# Altium schematic sheet 17 RGMII2.SchDoc
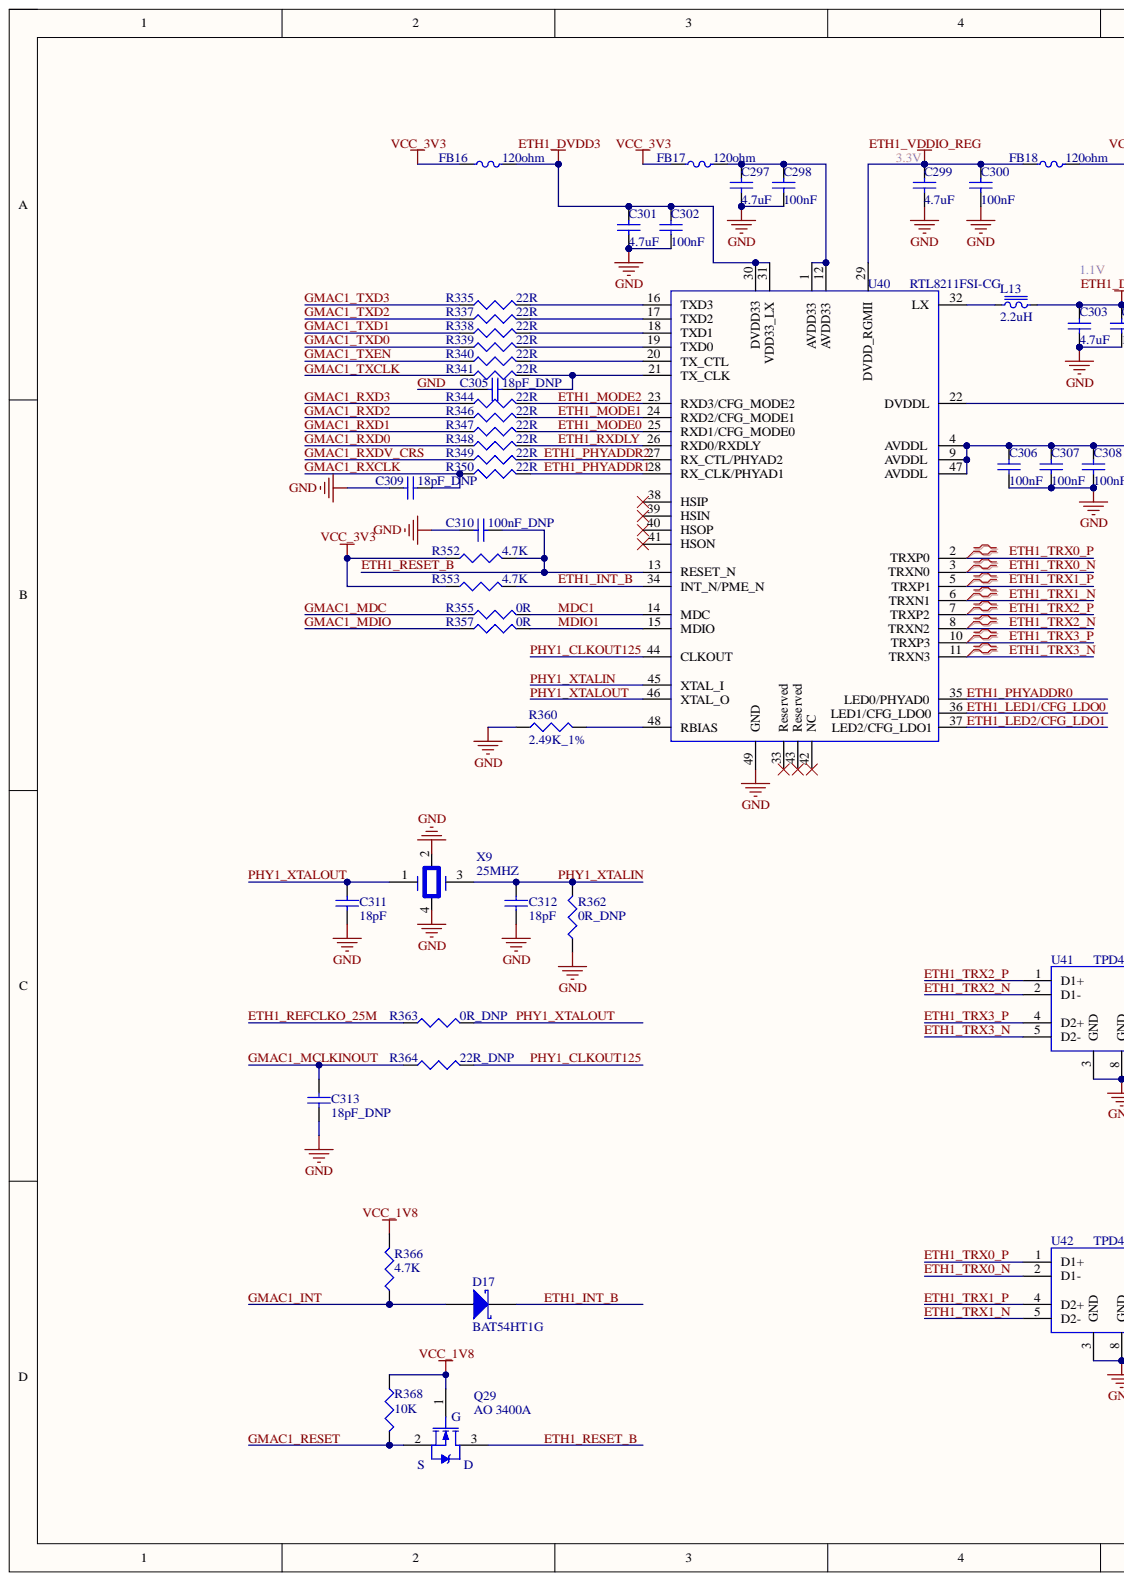
[diagram p0: 17 RGMII2.SchDoc, left]
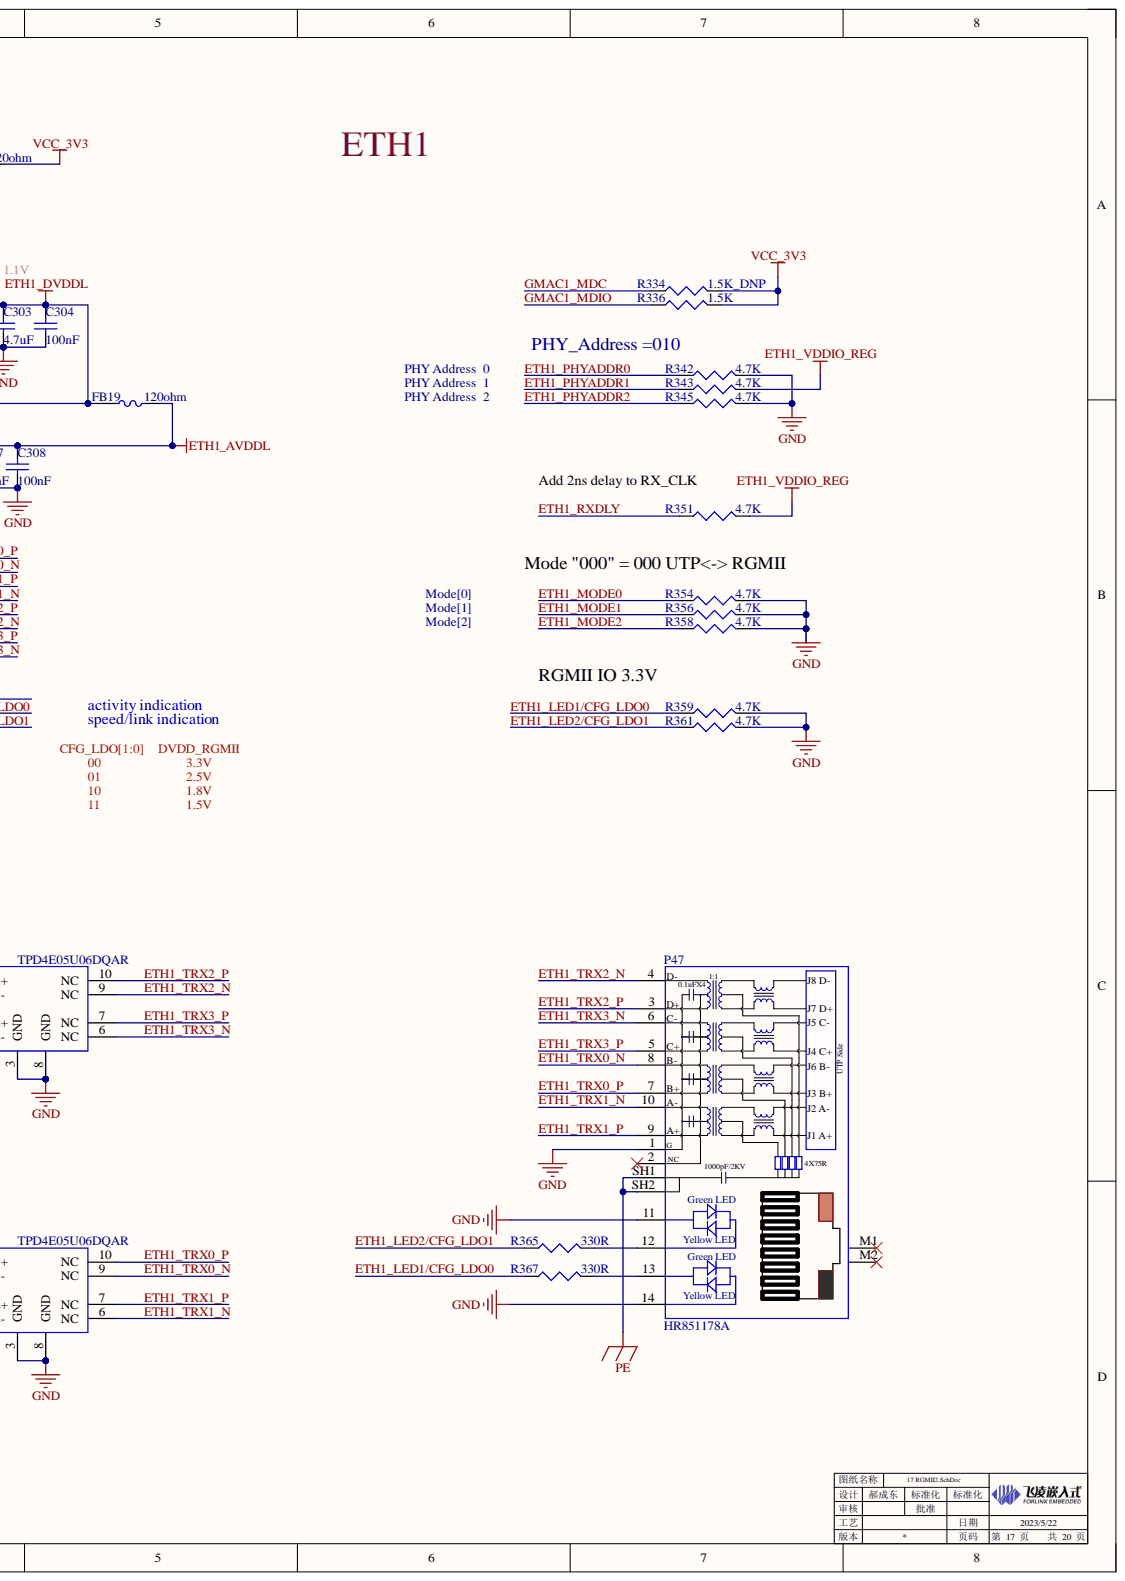
[diagram p1: 17 RGMII2.SchDoc, right]

## Parts
C297  4.7uF  [0402C]
C298  100nF  [0402C]
C299  4.7uF  [0402C]
C300  100nF  [0402C]
C301  4.7uF  [0402C]
C302  100nF  [0402C]
C303  4.7uF  [0402C]
C304  100nF  [0402C]
C305  18pF_DNP  [0402C]
C306  100nF  [0402C]
C307  100nF  [0402C]
C308  100nF  [0402C]
C309  18pF_DNP  [0402C]
C310  100nF_DNP  [0402C]
C311  18pF  [0402C]
C312  18pF  [0402C]
C313  18pF_DNP  [0402C]
D17  BAT54HT1G  [SOD_323]
FB16  120ohm  [0603L]
FB17  120ohm  [0603L]
FB18  120ohm  [0603L]
FB19  120ohm  [0603L]
L13  2.2uH  [WPN2016]
P47  HR851178A  [RJ45_Gigabit]
Q29  AO 3400A  [SOT_233_W]
R334  1.5K_DNP  [0402R]
R335  22R  [0402R]
R336  1.5K  [0402R]
R337  22R  [0402R]
R338  22R  [0402R]
R339  22R  [0402R]
R340  22R  [0402R]
R341  22R  [0402R]
R342  4.7K  [0402R]
R343  4.7K  [0402R]
R344  22R  [0402R]
R345  4.7K  [0402R]
R346  22R  [0402R]
R347  22R  [0402R]
R348  22R  [0402R]
R349  22R  [0402R]
R350  22R  [0402R]
R351  4.7K  [0402R]
R352  4.7K  [0402R]
R353  4.7K  [0402R]
R354  4.7K  [0402R]
R355  0R  [0402R]
R356  4.7K  [0402R]
R357  0R  [0402R]
R358  4.7K  [0402R]
R359  4.7K  [0402R]
R360  2.49K_1%  [0402R]
R361  4.7K  [0402R]
R362  0R_DNP  [0402R]
R363  0R_DNP  [0402R]
R364  22R_DNP  [0603R]
R365  330R  [0402R]
R366  4.7K  [0402R]
R367  330R  [0402R]
R368  10K  [0402R]
U40  RTL8211FSI-CG  [QFN48P_0.4BSC_6*6_H]
U41  TPD4E05U06DQAR  [USON-10]
U42  TPD4E05U06DQAR  [USON-10]
X9  25MHZ  [Crystals_3225]

## Nets
ETH1_AVDDL: C306.2 C307.2 C308.2 FB19.2 U40.4(AVDDL) U40.9(AVDDL) U40.47(AVDDL)
ETH1_DVDD3: C301.2 C302.2 FB16.2 U40.30(DVDD33) U40.31(VDD33_LX)
ETH1_DVDDL: C303.2 C304.2 FB19.1 L13.2 U40.22(DVDDL)
ETH1_VDDIO_REG: C299.2 C300.2 FB18.1 R343.2 R351.2 U40.29(DVDD_RGMII)
PE: P47.SH1 P47.SH2
VCC_1V8: Q29.1 R366.2 R368.1
VCC_3V3: FB16.1 FB17.1 FB18.2 R334.2 R336.2 R352.1 R353.1
ETH1_INT_B: D17.2 R353.2 U40.34(INT_N/PME_N)
ETH1_LED1/CFG_LDO0: R359.1 R367.1 U40.36(LED1/CFG_LDO0)
ETH1_LED2/CFG_LDO1: R361.1 R365.1 U40.37(LED2/CFG_LDO1)
ETH1_MODE0: R347.2 R354.1 U40.25(RXD1/CFG_MODE0)
ETH1_MODE1: R346.2 R356.1 U40.24(RXD2/CFG_MODE1)
ETH1_MODE2: R344.2 R358.1 U40.23(RXD3/CFG_MODE2)
ETH1_PHYADDR0: R342.1 U40.35(LED0/PHYAD0)
ETH1_PHYADDR1: R343.1 R350.2 U40.28(RX_CLK/PHYAD1)
ETH1_PHYADDR2: R345.1 R349.2 U40.27(RX_CTL/PHYAD2)
ETH1_REFCLKO_25M: R363.1
ETH1_RESET_B: C310.2 Q29.3 R352.2 U40.13(RESET_N)
ETH1_RXDLY: R348.2 R351.1 U40.26(RXD0/RXDLY)
ETH1_TRX0_N: P47.8(B-) U40.3(TRXN0) U42.2(D1-) U42.9(NC)
ETH1_TRX0_P: P47.7 U40.2(TRXP0) U42.1(D1+) U42.10(NC)
ETH1_TRX1_N: P47.10(A-) U40.6(TRXN1) U42.5(D2-) U42.6(NC)
ETH1_TRX1_P: P47.9 U40.5(TRXP1) U42.4(D2+) U42.7(NC)
ETH1_TRX2_N: P47.4 U40.8(TRXN2) U41.2(D1-) U41.9(NC)
ETH1_TRX2_P: P47.3(D+) U40.7(TRXP2) U41.1(D1+) U41.10(NC)
ETH1_TRX3_N: P47.6(C-) U40.11(TRXN3) U41.5(D2-) U41.6(NC)
ETH1_TRX3_P: P47.5(C+) U40.10(TRXP3) U41.4(D2+) U41.7(NC)
GMAC1_INT: D17.1 R366.1
GMAC1_MCLKINOUT: C313.2 R364.1
GMAC1_MDC: R334.1 R355.1
GMAC1_MDIO: R336.1 R357.1
GMAC1_RESET: Q29.2 R368.2
GMAC1_RXCLK: C309.2 R350.1
GMAC1_RXD0: R348.1
GMAC1_RXD1: R347.1
GMAC1_RXD2: R346.1
GMAC1_RXD3: R344.1
GMAC1_RXDV_CRS: R349.1
GMAC1_TXCLK: R341.1
GMAC1_TXD0: R339.1
GMAC1_TXD1: R338.1
GMAC1_TXD2: R337.1
GMAC1_TXD3: R335.1
GMAC1_TXEN: R340.1
GND: C297.1 C298.1 C299.1 C300.1 C301.1 C302.1 C303.1 C304.1 C305.1 C306.1 C307.1 C308.1 C309.1 C310.1 C311.1 C312.1 C313.1 P47.1 P47.11 P47.14 R342.2 R345.2 R354.2 R356.2 R358.2 R359.2 R360.1 R361.2 R362.1 U40.49(GND) U41.3(GND) U41.8(GND) U42.3(GND) U42.8(GND) X9.2 X9.4
MDC1: R355.2 U40.14(MDC)
MDIO1: R357.2 U40.15(MDIO)
PHY1_CLKOUT125: R364.2 U40.44(CLKOUT)
PHY1_XTALIN: C312.2 R362.2 U40.45(XTAL_I) X9.3
PHY1_XTALOUT: C311.2 R363.2 U40.46(XTAL_O) X9.1
NetC297_2: C297.2 C298.2 FB17.2 U40.1(AVDD33) U40.12(AVDD33)
NetC305_2: C305.2 R341.2 U40.21(TX_CLK)
NetL13_1: L13.1 U40.32(LX)
NetP47_12: P47.12 R365.2
NetP47_13: P47.13 R367.2
NetR335_2: R335.2 U40.16(TXD3)
NetR337_2: R337.2 U40.17(TXD2)
NetR338_2: R338.2 U40.18(TXD1)
NetR339_2: R339.2 U40.19(TXD0)
NetR340_2: R340.2 U40.20(TX_CTL)
NetR360_2: R360.2 U40.48(RBIAS)

Not connected: P47 2 M1 M2; U40 33(Reserved) 38(HSIP) 39(HSIN) 40(HSOP) 41(HSON) 42(NC) 43(Reserved)

## Library data of the parts
C297 C299 C301 C303 = 1110202032: Database Table Name: VALID$; 存货名称: 贴装叠层陶瓷电容; 序号: 616; 规格型号: 0402,4.7uF,10V,±10％,X5R; Component Kind: Standard; LatestRevisionDate: 17-Jul-2002; LatestRevisionNote: Re-released for DXP Platform.; Library Name: Database_AD Library.DbLib; PackageDescription: Radial Cap, Thru-Hole; 2 Leads; 0.3 in Pin Spacing; PackageReference: RAD-0.3; Published: 8-Jun-2000; Signal Integrity: Cap; Simulation: CAP; Value: 4.7uF; 存货编码: 1110202032; Publisher: Altium Limited
C298 C300 C302 C304 C306-C308 C310 = 1110202039: Database Table Name: VALID$; 存货名称: 贴装叠层陶瓷电容; 序号: 626; 规格型号: 0402,100nF,25V,±10％,X5R; Component Kind: Standard; LatestRevisionDate: 17-Jul-2002; LatestRevisionNote: Re-released for DXP Platform.; Library Name: Database_AD Library.DbLib; PackageDescription: Radial Cap, Thru-Hole; 2 Leads; 0.3 in Pin Spacing; PackageReference: RAD-0.3; Published: 8-Jun-2000; Signal Integrity: Cap; Simulation: CAP; 存货编码: 1110202039; Publisher: Altium Limited
  C298 C300 C302 C304 C306-C308: Value: 100nF
  C310: Value: 100nF_DNP
C305 C309 C311-C313 = 1110202008: Database Table Name: VALID$; 存货名称: 贴装叠层陶瓷电容; 序号: 578; 规格型号: 0402,18pF,50V,±5％,NPO; Component Kind: Standard; LatestRevisionDate: 17-Jul-2002; LatestRevisionNote: Re-released for DXP Platform.; Library Name: Database_AD Library.DbLib; PackageDescription: Radial Cap, Thru-Hole; 2 Leads; 0.3 in Pin Spacing; PackageReference: RAD-0.3; Published: 8-Jun-2000; Signal Integrity: Cap; Simulation: CAP; 存货编码: 1110202008; Publisher: Altium Limited
  C305 C309 C313: Value: 18pF_DNP
  C311 C312: Value: 18pF
D17 = 1110501028: Database Table Name: VALID$; 存货名称: 肖特基二极管; 序号: 2525; 规格型号: BAT54HT1G,SOD323; Code_JEDEC: DO-214-AA; Component Kind: Standard; LatestRevisionDate: 17-Jul-2002; LatestRevisionNote: Re-released for DXP Platform.; Library Name: Database_AD Library.DbLib; PackageDescription: DO-214-AA/SMB; 2 C-Bend Leads; Body 5.3 x 3.6 mm, inc. leads (LxW); PackageReference: SMB; Published: 8-Jun-2000; Signal Integrity: D Schottky; Simulation: SKYDIODE; Value: BAT54HT1G; 存货编码: 1110501028; Publisher: Altium Limited
FB16-FB19 = 1110304011: Database Table Name: VALID$; 主计量单位名称: 只; 存货名称: 磁珠; 安全库存: 12837.00; 序号: 1028; 旧编码: 010301092; 规格型号: 0603，120ohm; Component Kind: Standard; Library Name: Database_AD Library.DbLib; VALUE: 120ohm; 启用日期: 2015/3/11; 存货编码: 1110304011
L13 = 1110301085: Database Table Name: VALID$; 存货名称: 绕线电感; 规格型号: 2.2uH,2*1.6*1mm,2.15A,平行焊盘,非屏蔽,WPN201610H2R2MT; Component Kind: Standard; LatestRevisionDate: 17-Jul-2002; LatestRevisionNote: Re-released for DXP Platform.; Library Name: Database_AD Library.DbLib; PackageDescription: Axial Device, Thru-Hole; 2 Leads; 0.9 in Pin Spacing; PackageReference: AXIAL-0.9; Published: 24-Mar-1999; Signal Integrity: Inductor Iron; Simulation: INDUCTOR; Value: 2.2uH; 存货编码: 1110301085; Publisher: Altium Limited
P47 = 1120202071: Database Table Name: VALID$; 主计量单位名称: 个; 存货名称: 网口座; 安全库存: 672.00; 序号: 1656; 旧编码: 010402406; 规格型号: HR851178A RJ45 1000M 带灯 有变压器 无外围弹片; Component Kind: Standard; Library Name: Database_AD Library.DbLib; VALUE: HR851178A; 启用日期: 2018/1/5; 存货编码: 1120202071
Q29 = 1110505027: Database Table Name: VALID$; Note: Pin 2 is electrically connected to pin 4.; 存货名称: 场效应管; 描述栏信息: 替换1110505011; 规格型号: AO3400A,SOT23,N沟道; Component Kind: Standard; DatasheetVersion: PD-9.814; LatestRevisionDate: 17-Jul-2002; LatestRevisionNote: Re-released for DXP Platform.; Library Name: Database_AD Library.DbLib; PackageDescription: TO, Thru-Hole, Vertical, Heatsink Mounted; 3 In-Line Leads; Pitch 2.54 mm; PackageReference: TO-220AB; PackageVersion: Feb-1997; Published: 8-Jun-2000; Simulation: IRF1010; VALUE: AO 3400A; 存货编码: 1110505027; Publisher: Altium Limited
R334 R336 = 1110102021: Database Table Name: VALID$; 存货名称: 贴装电阻; 序号: 31; 规格型号: 0402,1.5K,±5％; Component Kind: Standard; Library Name: Database_AD Library.DbLib; Signal Integrity: Res; Simulation: RESISTOR; 存货编码: 1110102021
  R334: Value: 1.5K_DNP
  R336: Value: 1.5K
R335 R337-R341 R344 R346-R350 = 1110102028: Database Table Name: VALID$; 存货名称: 贴装电阻; 序号: 41; 规格型号: 0402,22Ω,±5％; Component Kind: Standard; Library Name: Database_AD Library.DbLib; Signal Integrity: Res; Simulation: RESISTOR; Value: 22R; 存货编码: 1110102028
R342 R343 R345 R351-R354 R356 R358 R359 R361 R366 = 1110102003: Database Table Name: VALID$; 存货名称: 贴装电阻; 序号: 12; 规格型号: 0402,4.7K,±5％; Component Kind: Standard; Library Name: Database_AD Library.DbLib; Signal Integrity: Res; Simulation: RESISTOR; Value: 4.7K; 存货编码: 1110102003
R355 R357 R362 R363 = 1110102001: Database Table Name: VALID$; 存货名称: 贴装电阻; 序号: 10; 规格型号: 0402,0Ω,±5％; Component Kind: Standard; Library Name: Database_AD Library.DbLib; Signal Integrity: Res; Simulation: RESISTOR; 存货编码: 1110102001
  R355 R357: Value: 0R
  R362 R363: Value: 0R_DNP
R360 = 1110102182: Database Table Name: VALID$; 存货名称: 贴装电阻; 描述栏信息: 厚声; 规格型号: 0402,2.49K,±1％; Component Kind: Standard; Library Name: Database_AD Library.DbLib; Signal Integrity: Res; Simulation: RESISTOR; Value: 2.49K_1%; 存货编码: 1110102182
R364 = 1110103009: Database Table Name: VALID$; 存货名称: 贴装电阻; 序号: 178; 规格型号: 0603,22Ω,±5％; Component Kind: Standard; Library Name: Database_AD Library.DbLib; Signal Integrity: Res; Simulation: RESISTOR; Value: 22R_DNP; 存货编码: 1110103009
R365 R367 = 1110102043: Database Table Name: VALID$; 存货名称: 贴装电阻; 序号: 56; 规格型号: 0402,330Ω,±5％; Component Kind: Standard; Library Name: Database_AD Library.DbLib; Signal Integrity: Res; Simulation: RESISTOR; Value: 330R; 存货编码: 1110102043
R368 = 1110102007: Database Table Name: VALID$; 存货名称: 贴装电阻; 序号: 17; 规格型号: 0402,10K,±5％; Component Kind: Standard; Library Name: Database_AD Library.DbLib; Signal Integrity: Res; Simulation: RESISTOR; Value: 10K; 存货编码: 1110102007
U40 = 1110405363: Database Table Name: VALID$; 存货名称: 常规IC; 规格型号: RTL8211FSI-CG,QFN48; Component Kind: Standard; Library Name: Database_AD Library.DbLib; Value: RTL8211FSI-CG; 存货编码: 1110405363
U41 U42 = 1110503037: Database Table Name: VALID$; 存货名称: TVS管; 规格型号: TPD4E05U06DQAR,USON; Component Kind: Standard; Library Name: Database_AD Library.DbLib; Value: TPD4E05U06DQAR; 存货编码: 1110503037
X9 = 1110601037: Database Table Name: VALID$; 存货名称: 贴装晶振; 序号: 3392; 规格型号: 无源,25MHZ,4P,3.2*2.5mm,±20ppm,20pf; Component Kind: Standard; Library Name: Database_AD Library.DbLib; Value: 25MHZ; 存货编码: 1110601037
